# Altium schematic sheet StudentForce_TENSO.SchDoc
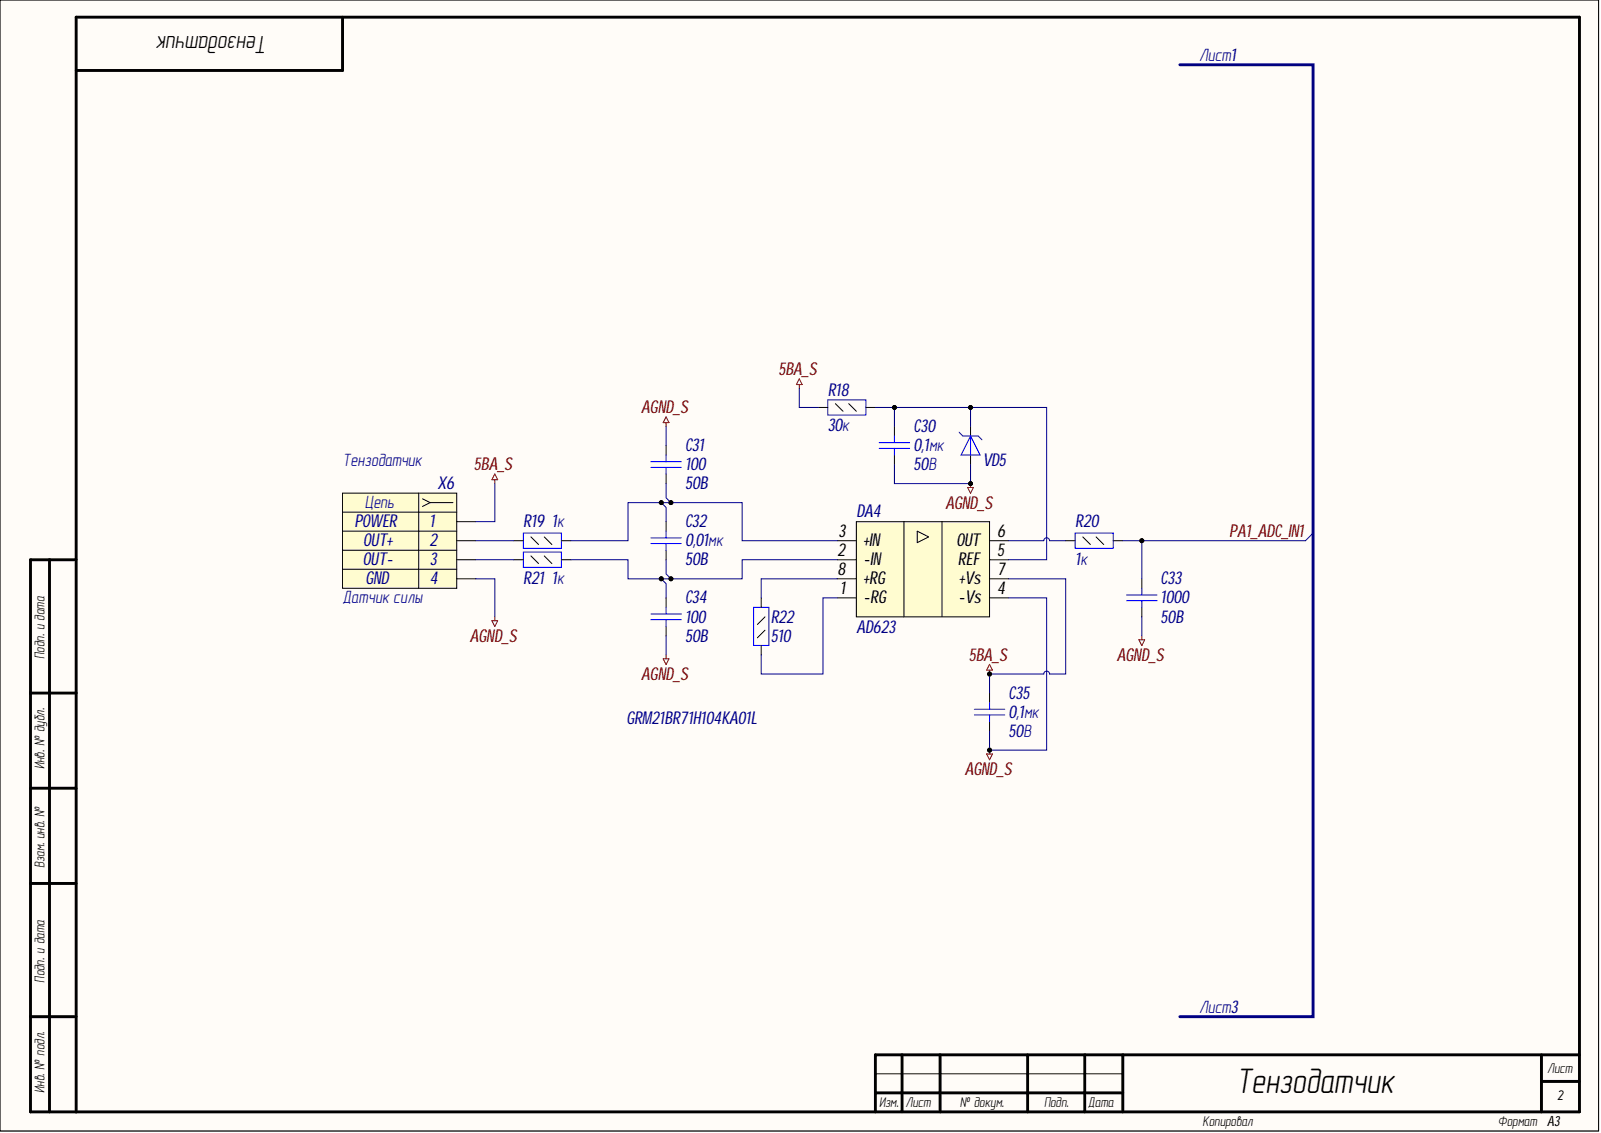
[diagram p0: StudentForce_TENSO.SchDoc]

## Parts
C30  value 0,1мк  Voltage: 50В  [C0805]  (hidden comment: Capacitor)
C31  value 100  Voltage: 50B  [C0603]  (hidden comment: Capacitor)
C32  value 0,01мк  Voltage: 50B  [C0805]  (hidden comment: Capacitor)
C33  value 1000  Voltage: 50B  [C0603]  (hidden comment: Capacitor)
C34  value 100  Voltage: 50B  [C0603]  (hidden comment: Capacitor)
C35  value 0,1мк  Voltage: 50В  [C0805]  (hidden comment: Capacitor)
DA4  AD623  [SO8]
R18  value 30к  [R0805]  (hidden comment: Resistor_0,125Вт)
R19  value 1к  [R0805]  (hidden comment: Resistor_0,125Вт)
R20  value 1к  [R0805]  (hidden comment: Resistor_0,125Вт)
R21  value 1к  [R0805]  (hidden comment: Resistor_0,125Вт)
R22  value 510  [R0805]  (hidden comment: Resistor_0,125Вт)
VD5  LM4040BIM3-2.5/NOPB  [SOT23-3]  (value not drawn)
X6  Датчик силы  Contact_1: POWER  Contact_2: OUT+  Contact_3: OUT-  Contact_4: GND  [CWF-4R]

## Nets
5BA_S: C35.2 DA4.7(+Vs) R18.1 X6.1
AGND_S: C30.1 C31.2 C33.1 C34.1 C35.1 DA4.4(-Vs) VD5.2 X6.4
PA1_ADC_IN1: C33.2 R20.2
NetC30_2: C30.2 DA4.5(REF) R18.2 VD5.1
NetC31_1: C31.1 C32.2 DA4.3(+IN) R19.2
NetC32_1: C32.1 C34.2 DA4.2(-IN) R21.2
NetDA4_1: DA4.1(-RG) R22.1
NetDA4_6: DA4.6(OUT) R20.1
NetDA4_8: DA4.8(+RG) R22.2
NetR19_1: R19.1 X6.2
NetR21_1: R21.1 X6.3

## Library data of the parts
C30 C35 = cap160: Конденсатор; Manufacture: Murata; Part Name: Ceramic; Part Number: GRM21BR71H104KA01L; Database Table Name: Capacitors$; TKE: X7R; Component Kind: Standard; id: cap160; Library Name: MOP44.DbLib
C31 C34 = cap91: Конденсатор; Manufacture: Murata; Part Name: Ceramic; Part Number: GRM1885C1H101J; Database Table Name: Capacitors$; TKE: NP0; Component Kind: Standard; id: cap91; Library Name: MOP44.DbLib
C32 = cap136: Конденсатор; Manufacture: Murata; Part Name: Ceramic; Part Number: GRM216R71H103K; Database Table Name: Capacitors$; TKE: X7R; Component Kind: Standard; id: cap136; Library Name: MOP44.DbLib
C33 = cap113: Конденсатор; Manufacture: Murata; Part Name: Ceramic; Part Number: GRM188R71H102K; Database Table Name: Capacitors$; TKE: X7R; Component Kind: Standard; id: cap113; Library Name: MOP44.DbLib
DA4 = amp12: Low Cost Low Power  Instrumentation Amplifier; Manufacture: Analog Devices; Part Number: AD623ARZ; Bandwidth: 800kHz; Channels: 1; CMRR: 110dB; Database Table Name: Amplifier$; Input Bias Current: 17nA; Offset voltage: 25uB; PSRR: 130dB; Rail-to-rail: yes; Supply Current max: 550uA; Supply Voltage: (+-)2,5-(+-)6B or 2,7-12B; Component Kind: Standard; id: amp12; Library Name: MOP44.DbLib; Part Name: Instrumentation Amplifier
R18 = res108: Резистор; Manufacture: Yageo; Part Number: RC0805FR-0730KL; Accuracy: 0.01; Database Table Name: Resistor$; Component Kind: Standard; id: res108; Library Name: MOP44.DbLib; Part Name: Resistor; Power: 0,125Вт
R19-R21 = res73: Резистор; Manufacture: Yageo; Part Number: RC0805FR-071KL; Accuracy: 0.01; Database Table Name: Resistor$; Component Kind: Standard; id: res73; Library Name: MOP44.DbLib; Part Name: Resistor; Power: 0,125Вт
R22 = res66: Резистор; Manufacture: Yageo; Part Number: RC0805FR-07510RL; Accuracy: 0,01; Database Table Name: Resistor$; Component Kind: Standard; id: res66; Library Name: MOP44.DbLib; Part Name: Resistor; Power: 0,125Вт
VD5 = LM4040BIM3-2.5/NOPB: Прецезионный источник опорного напряжения; Part Name: Precision Micropower Shunt Voltage Reference; Accuracy: 0.002; Database Table Name: VoltageReference$; id: ref0; Output current: 15mA; Voltage min stabilize: 2,5B; Component Kind: Standard; Library Name: MOP44.DbLib; Part Number: LM4040BIM3-2.5/NOPB
X6 = DS1069-4MRW6SA: Разъем - 4 конт. розетка; Database Table Name: Connector$; Component Kind: Standard; Contact_5: Contact_5; Library Name: MOP44.DbLib; Part Name: CWF-4R; Part Number: DS1069-4MRW6SA
